# Altium schematic sheet 14 Boot Configuration.SchDoc
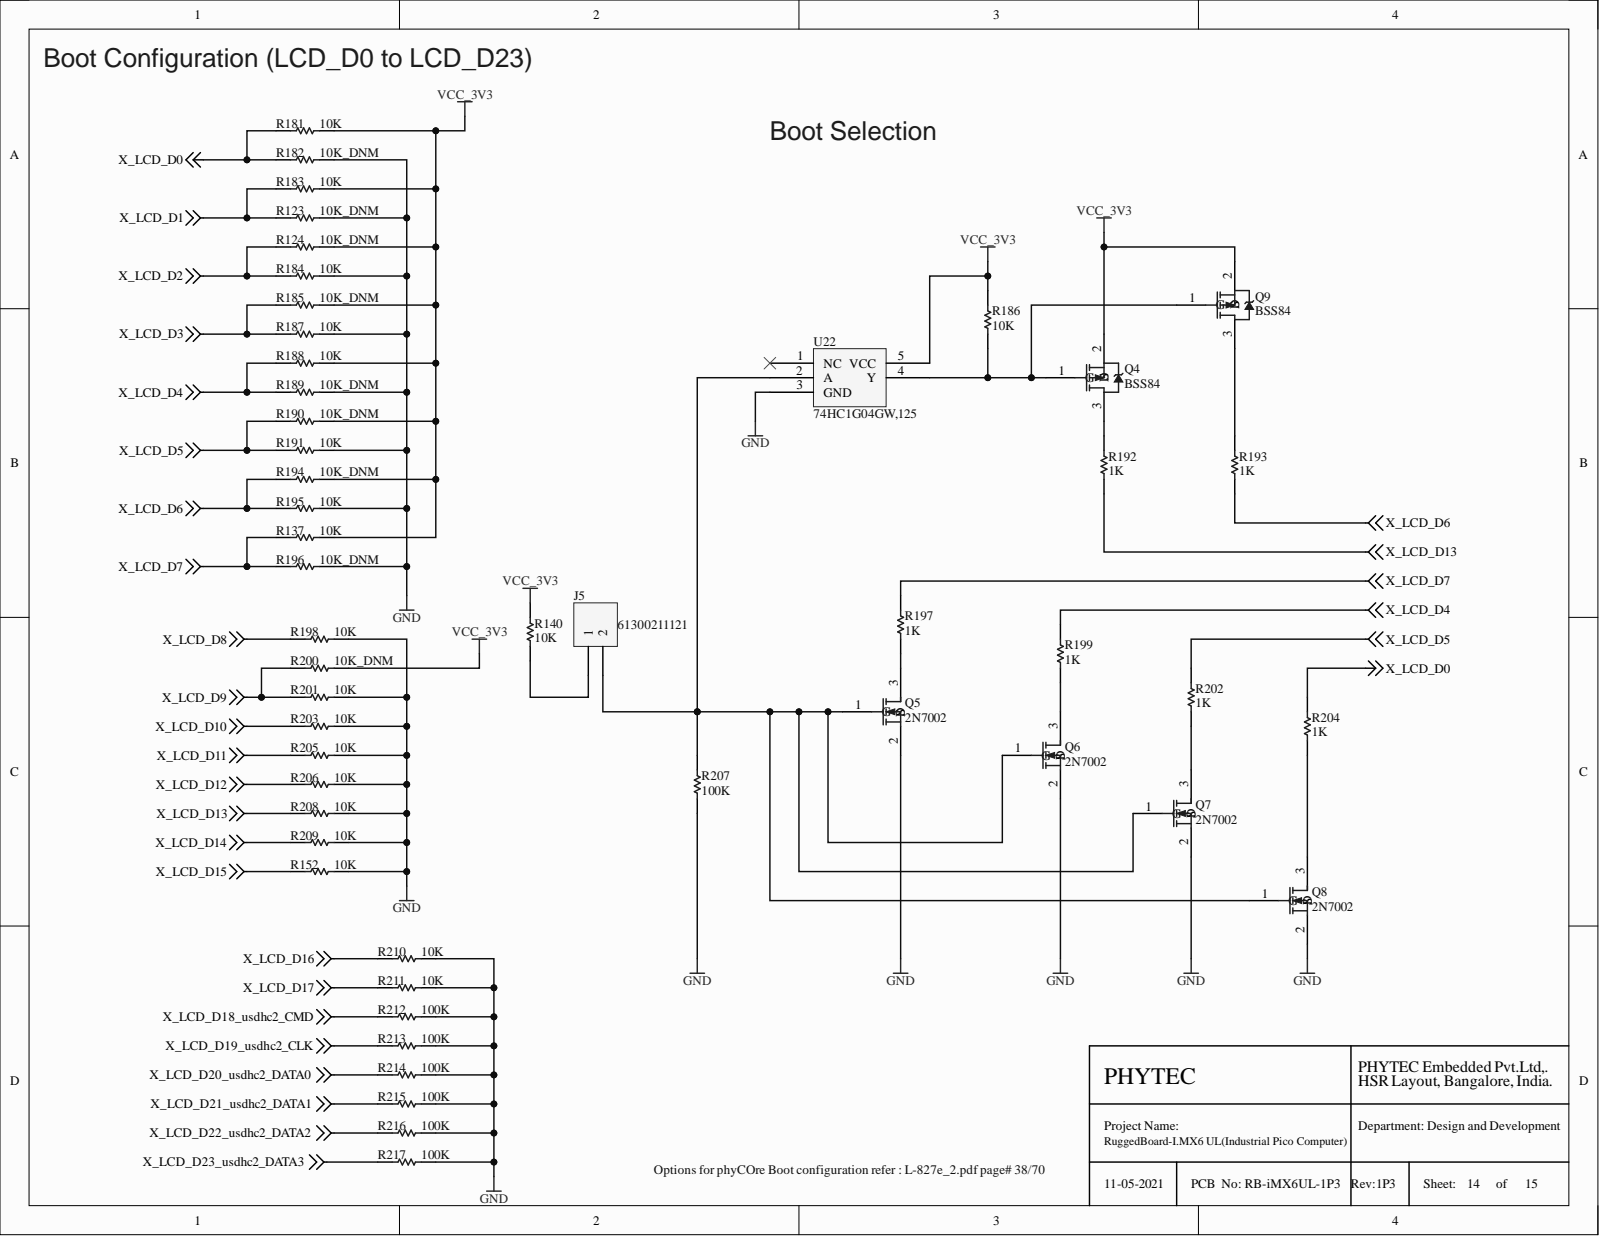
[diagram p0: 14 Boot Configuration.SchDoc]

## Parts
J5  61300211121  [HDR1X2]
Q4  BSS84  [SOT95P230X110-3N]
Q5  2N7002  [SOT95P230X110-3N]
Q6  2N7002  [SOT95P230X110-3N]
Q7  2N7002  [SOT95P230X110-3N]
Q8  2N7002  [SOT95P230X110-3N]
Q9  BSS84  [SOT95P230X110-3N]
R123  10K_DNM  [R0402]
R124  10K_DNM  [R0402]
R137  10K  [R0402]
R140  10K  [R0402]
R152  10K  [R0402]
R181  10K  [R0402]
R182  10K_DNM  [R0402]
R183  10K  [R0402]
R184  10K  [R0402]
R185  10K_DNM  [R0402]
R186  10K  [R0402]
R187  10K  [R0402]
R188  10K  [R0402]
R189  10K_DNM  [R0402]
R190  10K_DNM  [R0402]
R191  10K  [R0402]
R192  1K  [R0402]
R193  1K  [R0402]
R194  10K_DNM  [R0402]
R195  10K  [R0402]
R196  10K_DNM  [R0402]
R197  1K  [R0402]
R198  10K  [R0402]
R199  1K  [R0402]
R200  10K_DNM  [R0402]
R201  10K  [R0402]
R202  1K  [R0402]
R203  10K  [R0402]
R204  1K  [R0402]
R205  10K  [R0402]
R206  10K  [R0402]
R207  100K  [R0603]
R208  10K  [R0402]
R209  10K  [R0402]
R210  10K  [R0402]
R211  10K  [R0402]
R212  100K  [R0402]
R213  100K  [R0402]
R214  100K  [R0402]
R215  100K  [R0402]
R216  100K  [R0402]
R217  100K  [R0402]
U22  74HC1G04GW,125  [TSSOP65P205X110-5N]

## Nets
GND: Q5.2 Q6.2 Q7.2 Q8.2 R123.1 R152.1 R182.1 R184.1 R187.1 R189.1 R191.1 R195.1 R196.1 R198.1 R201.1 R203.1 R205.1 R206.1 R207.1 R208.1 R209.1 R210.1 R211.1 R212.1 R213.1 R214.1 R215.1 R216.1 R217.1 U22.3(GND)
VCC_3V3: Q4.2 Q9.2 R124.1 R137.1 R140.1 R181.1 R183.1 R185.1 R186.2 R188.1 R190.1 R194.1 R200.1 U22.5(VCC)
X_LCD_D0: R181.2 R182.2 R204.1
X_LCD_D1: R123.2 R183.2
X_LCD_D2: R124.2 R184.2
X_LCD_D3: R185.2 R187.2
X_LCD_D4: R188.2 R189.2 R199.1
X_LCD_D5: R190.2 R191.2 R202.1
X_LCD_D6: R193.2 R194.2 R195.2
X_LCD_D7: R137.2 R196.2 R197.1
X_LCD_D8: R198.2
X_LCD_D9: R200.2 R201.2
X_LCD_D10: R203.2
X_LCD_D11: R205.2
X_LCD_D12: R206.2
X_LCD_D13: R192.2 R208.2
X_LCD_D14: R209.2
X_LCD_D15: R152.2
X_LCD_D16: R210.2
X_LCD_D17: R211.2
X_LCD_D18_usdhc2_CMD: R212.2
X_LCD_D19_usdhc2_CLK: R213.2
X_LCD_D20_usdhc2_DATA0: R214.2
X_LCD_D21_usdhc2_DATA1: R215.2
X_LCD_D22_usdhc2_DATA2: R216.2
X_LCD_D23_usdhc2_DATA3: R217.2
NetJ5_1: J5.1 R140.2
NetJ5_2: J5.2 Q5.1 Q6.1 Q7.1 Q8.1 R207.2 U22.2(A)
NetQ4_1: Q4.1 Q9.1 R186.1 U22.4(Y)
NetQ4_3: Q4.3 R192.1
NetQ5_3: Q5.3 R197.2
NetQ6_3: Q6.3 R199.2
NetQ7_3: Q7.3 R202.2
NetQ8_3: Q8.3 R204.2
NetQ9_3: Q9.3 R193.1

Not connected: U22 1(NC)

## Library data of the parts
J5 = Header 2: CONN HEADER VERT 2POS 2.54MM; ASSEMBLY_TYPE: TH; MFG: WE; Component Kind: Standard; Library Name: Miscellaneous Connectors.IntLib; MFG_PN: 61300211121
Q4 Q9 = PMOS-2: MOSFET P-CH 50V 130MA SOT-23; ASSEMBLY_TYPE: SMT; MFG: Nexperia USA Inc.; MFG_PN: BSS84,215; Component Kind: Standard; Library Name: SCH_LIBRARY.SCHLIB; PACKAGE: SOT-23; Simulation: IRF9510
Q5-Q8 = MOSFET-N: MOSFET N-CH 60V 0.36A SOT-23; ASSEMBLY_TYPE: SMT; MFG: Nexperia USA Inc.; MFG_PN: 2N7002P,215; Component Kind: Standard; Library Name: Miscellaneous Devices.IntLib; PACKAGE: SOT23-3; Simulation: NMOS
R123 R124 R137 R140 R152 R181-R191 R194-R196 R198 R200 R201 R203 R205 R206 R208-R217 = Res1: RES SMD 10K OHM 1% 1/16W 0402; MFG: YAGEO; MFG_PN: RC0402FR-0710KL; Component Kind: Standard; Library Name: Miscellaneous Devices.IntLib; PACKAGE: 0402; PACKAGE_TYPE: SMT; Signal Integrity: Res; Simulation: RESISTOR
R192 R193 R197 R199 R202 R204 = Res1: RES SMD 1K OHM 1% 1/16W 0402; MFG: YAGEO; MFG_PN: RC0402FR-071KL; Component Kind: Standard; Library Name: Miscellaneous Devices.IntLib; PACKAGE: 0402; PACKAGE_TYPE: SMT; Signal Integrity: Res; Simulation: RESISTOR
R207 = Res1: RES SMD 100K OHM 1% 1/10W 0603; ASSEMBLY_TYPE: SMT; MFG: YAGEO; MFG_PN: RC0603FR-07100KL; Component Kind: Standard; Library Name: Miscellaneous Devices.IntLib; PACKAGE: 0603
U22 = 74HC1G04: IC INVERTER 5TSSOP; ASSEMBLY_TYPE: SMD; MFG: Nexperia USA Inc.; Component Kind: Standard; Library Name: TVS i,MX6 Mother Board.SCHLIB; MFG_PN: 74HC1G04GW,125; PACKAGE: 5-TSSOP
